# Altium schematic sheet Signalight_2.SchDoc
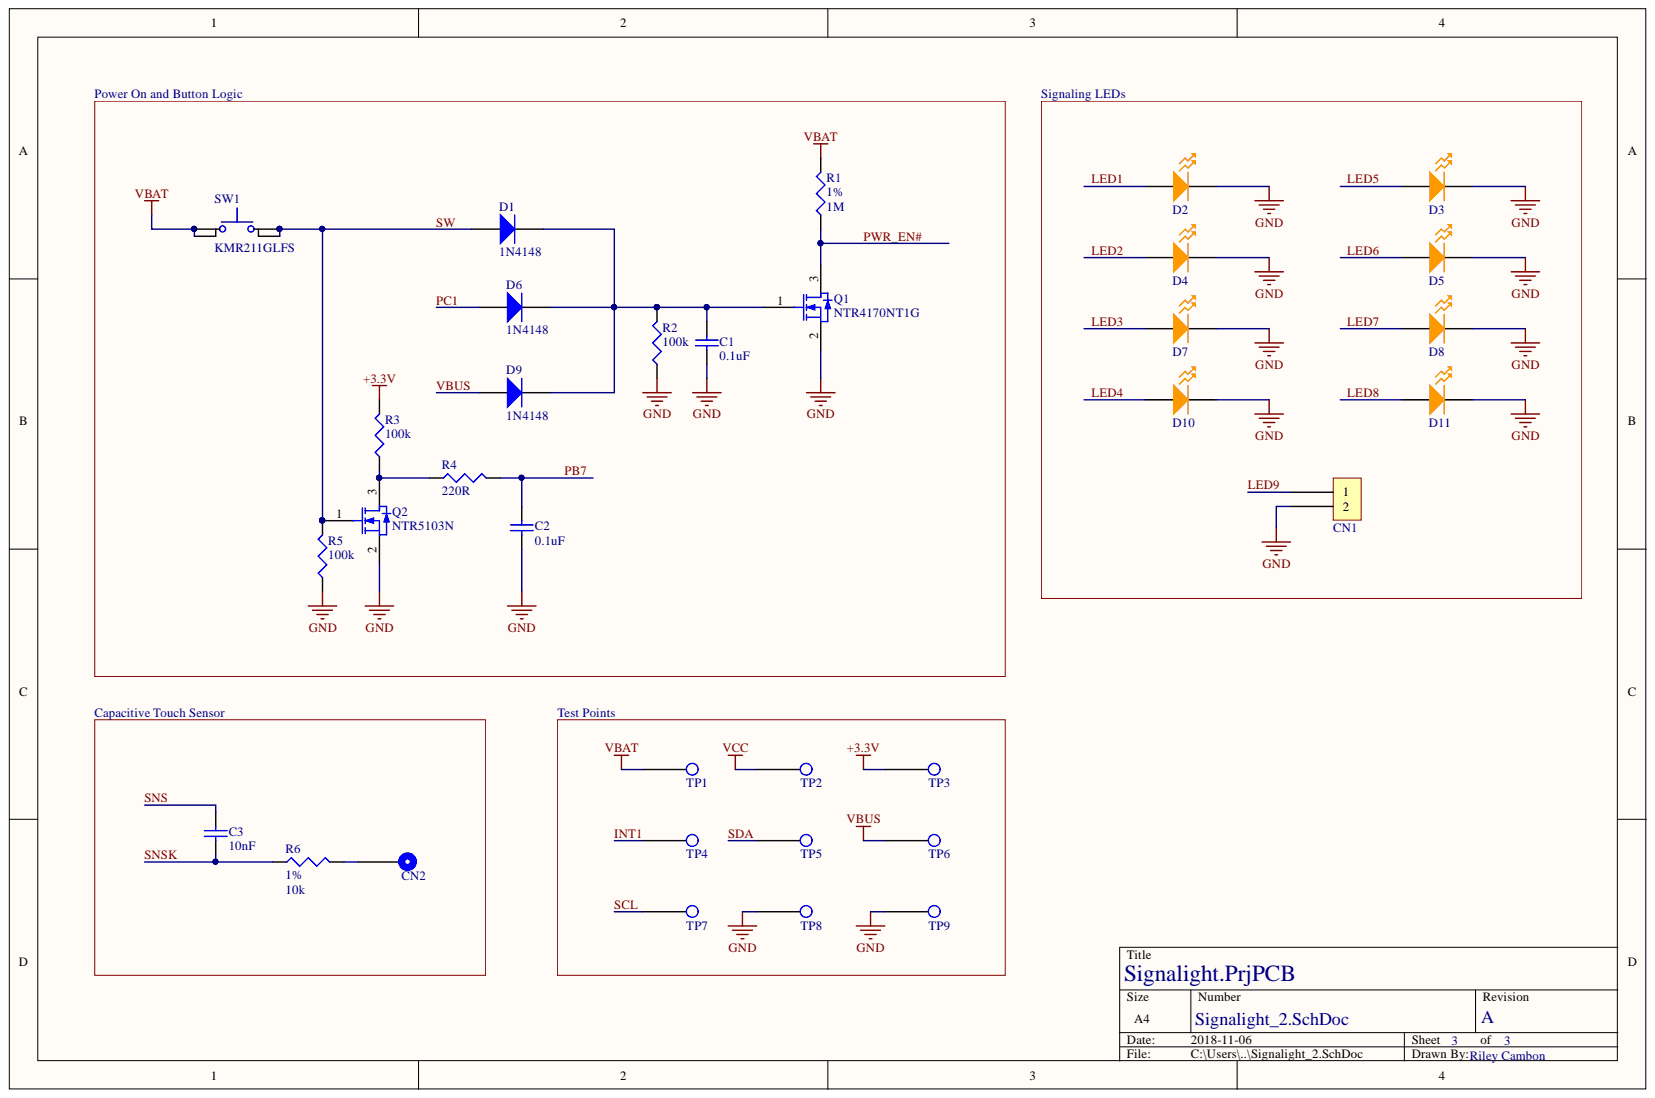
[diagram p0: Signalight_2.SchDoc]

## Parts
C1  value 0.1uF  [CAP CER 0.1UF 16V X7R 0603]  (hidden comment: CAP CER 0.1uF 10% 10V X7R 0603_1)
C2  value 0.1uF  [CAP CER 0.1UF 16V X7R 0603]  (hidden comment: CAP CER 0.1uF 10% 10V X7R 0603_1)
C3  value 10nF  [CAP CER 0.1UF 16V X7R 0603]  (hidden comment: CAP CER 10000PF 100V X7R 0603)
CN1  CONN_2PIN_PWR  [CONN_2PIN_TH]  (value not drawn)
CN2  Capacitive touch connection - 4mm  [Capacitive touch connection - 4mm]  (value not drawn)
D1  Manufactuer Part Number: 1N4148  [SOD-523]  (hidden comment: 1N4148_523)
D2  HSMA_AMBER  [HSMx-A10x-xxxxx]  (value not drawn)
D3  HSMA_AMBER  [HSMx-A10x-xxxxx]  (value not drawn)
D4  HSMA_AMBER  [HSMx-A10x-xxxxx]  (value not drawn)
D5  HSMA_AMBER  [HSMx-A10x-xxxxx]  (value not drawn)
D6  Manufactuer Part Number: 1N4148  [SOD-523]  (hidden comment: 1N4148_523)
D7  HSMA_AMBER  [HSMx-A10x-xxxxx]  (value not drawn)
D8  HSMA_AMBER  [HSMx-A10x-xxxxx]  (value not drawn)
D9  Manufactuer Part Number: 1N4148  [SOD-523]  (hidden comment: 1N4148_523)
D10  HSMA_AMBER  [HSMx-A10x-xxxxx]  (value not drawn)
D11  HSMA_AMBER  [HSMx-A10x-xxxxx]  (value not drawn)
Q1  NTR4170NT1G  [NTR5103N]
Q2  NTR5103N  [NTR5103N]
R1  value 1M  Tolerance: 1%  [RES_0603_YAGEO]  (hidden comment: RES  1M 1% 1/10W 0603)
R2  value 100k  [RES_0603_YAGEO]  (hidden comment: RES 100K 1% 1/10W 0603)
R3  value 100k  [RES_0603_YAGEO]  (hidden comment: RES 100K 1% 1/10W 0603)
R4  value 220R  [RES_0603_YAGEO]  (hidden comment: RES 220R 1% 1/10W 0603)
R5  value 100k  [RES_0603_YAGEO]  (hidden comment: RES 100K 1% 1/10W 0603)
R6  value 10k  Tolerance: 1%  [RES_0603_YAGEO]  (hidden comment: RES 10K 1% 1/10W 0603)
SW1  KMR2 - SPST  [KMR211GLFS]  (value not drawn)
TP1  Test Point  [TP]
TP2  Test Point  [TP]
TP3  Test Point  [TP]
TP4  Test Point  [TP]
TP5  Test Point  [TP]
TP6  Test Point  [TP]
TP7  Test Point  [TP]
TP8  Test Point  [TP]
TP9  Test Point  [TP]

## Nets
+3.3V: R3.2 TP3.1
GND: C1.1 C2.1 CN1.2 D2.2 D3.2 D4.2 D5.2 D7.2 D8.2 D10.2 D11.2 Q1.2 Q2.2 R2.1 R5.1 TP8.1 TP9.1
VBAT: R1.2 SW1.1 SW1.2 TP1.1
VCC: TP2.1
INT1: TP4.1
LED1: D2.1
LED2: D4.1
LED3: D7.1
LED4: D10.1
LED5: D3.1
LED6: D5.1
LED7: D8.1
LED8: D11.1
LED9: CN1.1
PB7: C2.2 R4.2
PC1: D6.1
PWR_EN#: Q1.3 R1.1
SCL: TP7.1
SDA: TP5.1
SNS: C3.1
SNSK: C3.2 R6.1
SW: D1.1 Q2.1 R5.2 SW1.3 SW1.4
VBUS: D9.1 TP6.1
NetC1_2: C1.2 D1.2 D6.2 D9.2 Q1.1 R2.2
NetCN2_1: CN2.1 R6.2
NetQ2_3: Q2.3 R3.1 R4.1

## Library data of the parts
C1 C2 = CAP CER 0.1uF 10% 10V X7R 0603_1: CAP CER 0.1uF 10% 16V X7R 0603; Component Kind: Standard; ComponentLink1Description: Datasheet; ComponentLink1URL: http://search.murata.co.jp/Ceramy/image/img/A01X/G101/ENG/GRM188R71C104KA01-01.pdf; ComponentLink2Description: Digikey; ComponentLink2URL: https://www.digikey.ca/product-detail/en/murata-electronics-north-america/GRM188R71C104KA01D/490-1532-1-ND/587771; Library Name: Capacitors.IntLib; Package: 0603; Temp Coefficient: X7R; Tolerance: 10%; Voltage Rating: 16V
C3 = CAP CER 10000PF 100V X7R 0603: Tolerance: 10%; Component Kind: Standard; ComponentLink1Description: Datasheet; ComponentLink1URL: https://psearch.en.murata.com/capacitor/product/GCM188R72A103KA37%23.pdf; ComponentLink2Description: Digikey; ComponentLink2URL: https://www.digikey.ca/product-detail/en/murata-electronics-north-america/GCM188R72A103KA37D/490-4781-1-ND/1641700; Description: CAP CER 10000PF 100V X7R 0603; Library Name: Capacitors.IntLib; Package: 0603; Temp Coefficient: X7R; Voltage Rating: 100V
CN1 = CONN_2PIN_TH: Component Kind: Standard; Description: CONN_2PIN_PWR; Library Name: Connectors.IntLib
CN2 = Capacitive touch connection - 4mm: Test Point; Component Kind: Standard; Library Name: MISC.IntLib
D1 D6 D9 = 1N4148_523: Diode SILICON 150mA SOD-523; Forward Voltage: 0.7; Component Kind: Standard; ComponentLink1Description: Datasheet; ComponentLink1URL: http://www.mccsemi.com/up_pdf/1N4148X(SOD-523).PDF; ComponentLink2Description: Digikey; ComponentLink2URL: https://www.digikey.ca/product-detail/en/micro-commercial-co/1N4148X-TP/1N4148XTPMSCT-ND/789335; Library Name: Diodes.IntLib; Package: SOD-523
D2-D5 D7 D8 D10 D11 = HSMA_AMBER: LED AMBER CLEAR 2PLCC SMD; Manufacturer Part Number: HSMA-A100-Q00J1; Forward Voltage: 1.9V; Component Kind: Standard; ComponentLink1Description: Datasheet; ComponentLink1URL: https://media.digikey.com/pdf/Data%20Sheets/Avago%20PDFs/HSMx-A10x-xxxxx.pdf; ComponentLink2Description: Digikey; ComponentLink2URL: https://www.digikey.ca/product-detail/en/broadcom-limited/HSMA-A100-Q00J1/516-2119-1-ND/1990554; Library Name: Diodes.IntLib; Package: 2PLCC
Q1 = NTR4170NT1G: MOSFET N-CH 30V 3.2A SOT23; Vgs On: 2.5 to 10V; Component Kind: Standard; ComponentLink1Description: Datasheet; ComponentLink1URL: http://www.onsemi.com/pub/Collateral/NTR4170N-D.PDF; ComponentLink2Description: Digikey; ComponentLink2URL: https://www.digikey.ca/product-detail/en/on-semiconductor/NTR4170NT1G/NTR4170NT1GOSCT-ND/2623483; Current Rating: 3.2A; Library Name: Transistors.IntLib; Manufacturer Part Number: NTR4170NT1G; Package: SOT-23-3L; Type: N-Ch; Vdss Max: 30V
Q2 = NTR5103N: MOSFET N-CH 60V 0.26A SOT23; Current Rating: 260mA; Vdss Max: 30V; Vgs On: 4.5 to 10V; Component Kind: Standard; ComponentLink1Description: Datasheet; ComponentLink1URL: http://www.onsemi.com/pub/Collateral/NTR5103N-D.PDF; ComponentLink2Description: Digikey; ComponentLink2URL: https://www.digikey.com/product-detail/en/on-semiconductor/NTR5103NT1G/NTR5103NT1GOSCT-ND/5801875; Library Name: Transistors.IntLib; Manufacturer Part Number: NTR5103N; Package: SOT-23-3L; Type: N-Ch
R1 = RES  1M 1% 1/10W 0603: RES 1M 1% 1/10W 0603; Component Kind: Standard; ComponentLink1Description: Datasheet; ComponentLink1URL: http://www.yageo.com/documents/recent/PYu-RC_Group_51_RoHS_L_8.pdf; ComponentLink2Description: Digikey; ComponentLink2URL: https://www.digikey.ca/product-detail/en/yageo/RC0603FR-07470RL/311-470HRCT-ND/730203; Library Name: Resistors.IntLib; Power Rating: 1/10W; Size: 0603
SW1 = KMR2 - SPST: SWITCH TACTILE SPST-NO 0.05A 32V; Current Rating: 20mA; Component Kind: Standard; ComponentLink1Description: Datasheet; ComponentLink1URL: https://www.ckswitches.com/media/1479/kmr2.pdf; ComponentLink2Description: Digikey; ComponentLink2URL: https://www.digikey.ca/product-detail/en/c-k/KMR211GLFS/401-1426-1-ND/550461; Digikey part number: KMR211GLFS; Library Name: MISC.IntLib; Voltage Rating: 32V
R2 R3 R5 = RES 100K 1% 1/10W 0603: Component Kind: Standard; ComponentLink1Description: Datasheet; ComponentLink1URL: http://www.yageo.com/documents/recent/PYu-RC_Group_51_RoHS_L_8.pdf; ComponentLink2Description: Digikey; ComponentLink2URL: https://www.digikey.ca/product-detail/en/yageo/RC0603FR-07100KL/311-100KHRDKR-ND/732783; Description: RES 100K 1% 1/10W 0603; Library Name: Resistors.IntLib; Power Rating: 1/10W; Size: 0603; Tolerance: 1%
R4 = RES 220R 1% 1/10W 0603: Component Kind: Standard; ComponentLink1Description: Datasheet; ComponentLink1URL: http://www.yageo.com/documents/recent/PYu-RC_Group_51_RoHS_L_8.pdf; ComponentLink2Description: Digikey; ComponentLink2URL: https://www.digikey.com/product-detail/en/yageo/RC0603FR-07220RL/311-220HRCT-ND/730008; Description: RES 220R 1% 1/10W 0603; Library Name: Resistors.IntLib; Power Rating: 1/10W; Size: 0603; Tolerance: 1%
R6 = RES 10K 1% 1/10W 0603: Component Kind: Standard; ComponentLink1Description: Datasheet; ComponentLink1URL: http://www.yageo.com/documents/recent/PYu-RC_Group_51_RoHS_L_8.pdf; ComponentLink2Description: Digikey; ComponentLink2URL: https://www.digikey.ca/product-detail/en/yageo/RC0603FR-0710KL/311-10.0KHRCT-ND/729827; Description: RES 10K 1% 1/10W 0603; Library Name: Resistors.IntLib; Power Rating: 1/10W; Size: 0603
TP1-TP9 = Test Point: Component Kind: Standard; Description: Test Point; Library Name: MISC.IntLib
